# Altium schematic sheet Infrared Pro_ESP.SchDoc("Infrared Pro_ESP")
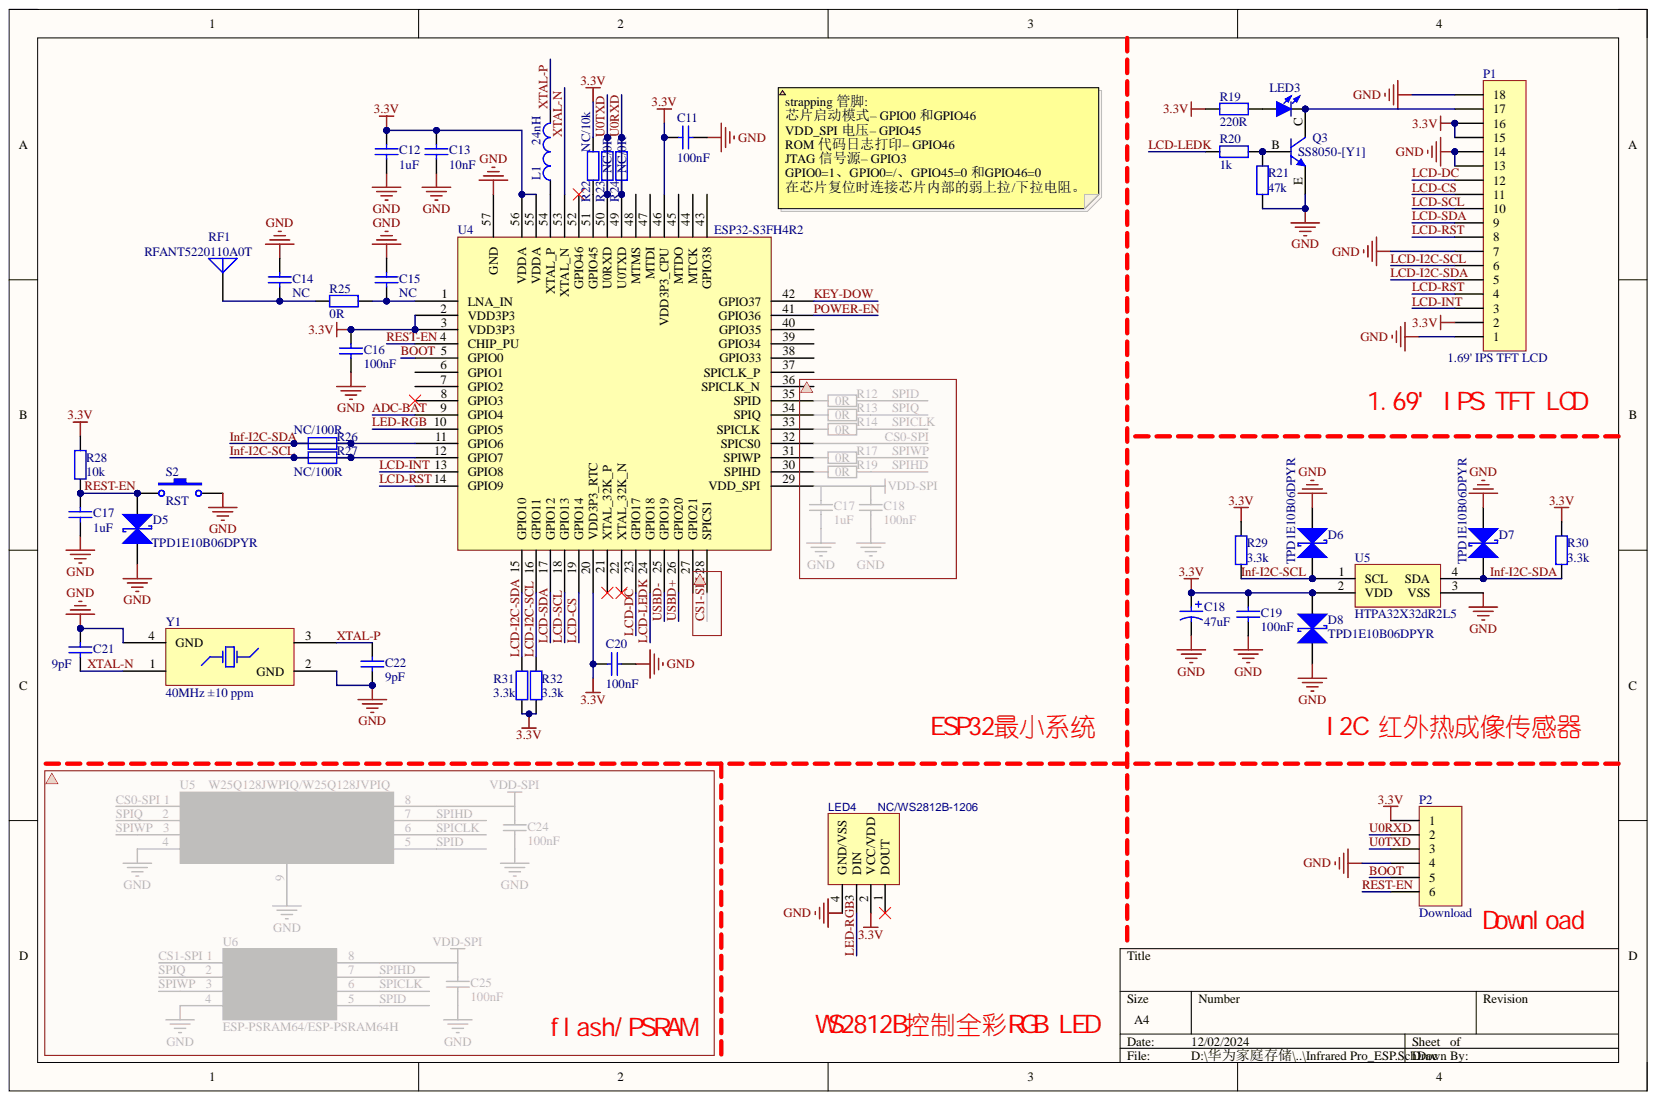
[diagram p0: Infrared Pro_ESP.SchDoc]

## Parts
C11  100nF  [C-RAD-0402-L]
C12  1uF  [C-RAD-0402-L]
C13  10nF  [C-RAD-0402-L]
C14  NC  [C-RAD-0402-L]
C15  NC  [C-RAD-0402-L]
C16  100nF  [C-RAD-0402-L]
C17  1uF  [C-RAD-0402-L]
C18  47uF  [C-CD A(3216-18)]
C19  100nF  [C-RAD-0402-L]
C20  100nF  [C-RAD-0402-L]
C21  9pF  [C-RAD-0402-L]
C22  9pF  [C-RAD-0402-L]
D5  TPD1E10B06DPYR  [X1-SON-2(0.6x1)]
D6  TPD1E10B06DPYR  [X1-SON-2(0.6x1)]
D7  TPD1E10B06DPYR  [X1-SON-2(0.6x1)]
D8  TPD1E10B06DPYR  [X1-SON-2(0.6x1)]
L1  24nH  [L 0402]
LED3  LED-SMD  [LED 0603-W-Side]  (value not drawn)
LED4  NC/WS2812B-1206  [LED-SMD-WS2812B-1206]
P1  1.69' IPS TFT LCD  [FPC_0.5_18P]
P2  Download  [TP-1.27mmx6]
Q3  SS8050-[Y1]  [Q-NPN-SOT23]
R19  220R  [RES-0402-L (1/16W)]
R20  1k  [RES-0402-L (1/16W)]
R21  47k  [RES-0402-L (1/16W)]
R22  NC/10k  [RES-0402-L (1/16W)]
R23  NC/0R  [RES-0402-L (1/16W)]
R24  NC/0R  [RES-0402-L (1/16W)]
R25  0R  [RES-0402-L (1/16W)]
R26  NC/100R  [RES-0402-L (1/16W)]
R27  NC/100R  [RES-0402-L (1/16W)]
R28  10k  [RES-0402-L (1/16W)]
R29  3.3k  [RES-0402-L (1/16W)]
R30  3.3k  [RES-0402-L (1/16W)]
R31  3.3k  [RES-0402-L (1/16W)]
R32  3.3k  [RES-0402-L (1/16W)]
RF1  RFANT5220110A0T  [ANT-AN5220]
S2  RST  [SW-SMD_YD-3410]
U4  ESP32-S3FH4R2  [QFN-56(7x7)]
U5  HTPA32X32dR2L5  [HTPA32X32dR2L5_B]
Y1  40MHz ±10 ppm  [Y-SMD 2016]

## Nets
3.3V: C11.1 C12.2 C13.2 C16.2 C18.1 C19.2 C20.1 D8.K LED4.2 P1.2 P1.15 P1.16 P2.1 R19.1 R22.2 R28.2 R29.2 R30.2 R31.1 R32.1 U4.2 U4.3 U4.20 U4.46 U4.55 U4.56 U5.2
GND: C11.2 C12.1 C13.1 C14.2 C15.2 C16.1 C17.1 C18.2 C19.1 C20.2 C21.2 C22.1 D5.A D6.K D7.K D8.A LED4.4 P1.1 P1.7 P1.13 P1.14 P1.18 P2.4 Q3.E R21.1 S2.1 U4.57 U5.3 Y1.2 Y1.4
ADC-BAT: U4.9
BOOT: P2.5 U4.5
Inf-I2C-SCL: D6.A R27.1 R27.2 R29.1 U4.12 U5.1
Inf-I2C-SDA: D7.A R26.1 R26.2 R30.1 U4.11 U5.4
KEY-DOW: U4.42
LCD-CS: P1.11 U4.19
LCD-DC: P1.12 U4.23
LCD-I2C-SCL: P1.6 R32.2 U4.16
LCD-I2C-SDA: P1.5 R31.2 U4.15
LCD-INT: P1.3 U4.13
LCD-LEDK: R20.2 U4.24
LCD-RST: P1.4 P1.8 U4.14
LCD-SCL: P1.10 U4.18
LCD-SDA: P1.9 U4.17
LED-RGB: LED4.3 U4.10
POWER-EN: U4.41
REST-EN: C17.2 D5.K P2.6 R28.1 S2.2 U4.4
U0RXD: P2.2 R24.1 R24.2 U4.49
U0TXD: P2.3 R23.1 R23.2 U4.50
USBD+: U4.26
USBD-: U4.25
XTAL-N: C21.1 U4.53 Y1.1
XTAL-P: C22.2 L1.2 Y1.3
NetC14_1: C14.1 R25.1 RF1.1
NetC15_1: C15.1 R25.2 U4.1
NetL1_1: L1.1 U4.54
NetLED3_1: LED3.1 R19.2
NetLED3_2: LED3.2 P1.17 Q3.C
NetQ3_B: Q3.B R20.1 R21.2
NetR22_1: R22.1 U4.51
Buzzer:
Motor:

Not connected: LED4 1; U4 6 7 8 21 22 27 28 29 30 31 32 33 34 35 36 37 38 39 40 43 44 45 47 48 52

## Library data of the parts
C11-C17 C19-C22 = Cap: Capacitor; Component Kind: Standard; LatestRevisionDate: 17-Jul-2002; LatestRevisionNote: Re-released for DXP Platform.; Library Name: Master Li.SchLib; PackageDescription: Radial Cap, Thru-Hole; 2 Leads; 0.3 in Pin Spacing; PackageReference: RAD-0.3; Published: 8-Jun-2000; Publisher: Altium Limited
  C11 C16 C19 C20: Value: 100nF
  C12 C17: Value: 1uF
  C13: Value: 10nF
  C14 C15: Value: NC
  C21 C22: Value: 9pF
C18 = Cap(tantalum): tantalum capacitor; Component Kind: Standard; Library Name: Master Li.SchLib; Value: 47uF
D5-D8 = Diode-TVS: 瞬变抑制二极管   TRANSIENT VOLTAGE SUPPRESSOR; Component Kind: Standard; LatestRevisionDate: 29-Mar-2024; LatestRevisionNote: Re-released for DXP Platform.; Library Name: Master Li.SchLib; Published: 29-Mar-2024; Publisher: Altium Limited
L1 = L-Inductor: 电感、磁珠; Code_IEC: 1005; Value: 100uH; Code_JEITA: 0402; Component Kind: Standard; LatestRevisionDate: 29-May-2009; LatestRevisionNote: IPC-7351 Footprint Added.; Library Name: Master Li.SchLib; PackageDescription: Chip Inductor; PackageReference: 0402-A; Published: 8-Jun-2000; Publisher: Altium Limited
LED3 = LED-SMD: 贴片LED; 版权归属: Master Li; BOM名称: 贴片LED; Component Kind: Standard; Library Name: Master Li.SchLib; 学习资源: https://shop237247974.taobao.com/
LED4 = LED-WS2812B-1206: 1206侧发光; BOM_Manufacturer: Worldsemi; BOM_Manufacturer Part: WS2812B-4020; BOM_JLCPCB Part Class: Extended Part; BOM_Supplier: LCSC; BOM_Supplier Part: C965557; Component Kind: Standard; Library Name: Master Li.SchLib; spicePre: L; spiceSymbolName: WS2812B-4020
P1 = Header 1x18: Header, 1x18-Pin; Component Kind: Standard; LatestRevisionDate: 17-Jul-2002; LatestRevisionNote: Re-released for DXP Platform.; Library Name: Master Li.SchLib; Publisher: Altium Limited
P2 = Header 1x6: Header, 1x6-Pin; Component Kind: Standard; LatestRevisionDate: 17-Jul-2002; LatestRevisionNote: Re-released for DXP Platform.; Library Name: Master Li.SchLib; Publisher: Altium Limited
Q3 = Q-NPN: NPN Bipolar Transistor; Code_JEDEC: TO-226-AA; Component Kind: Standard; LatestRevisionDate: 17-Jul-2002; LatestRevisionNote: Re-released for DXP Platform.; Library Name: Master Li.SchLib; PackageDescription: TO, Flat Index; 3 In-Line, Axial Leads; Body Dia. 4.8mm; Leads 0.55 x 0.51 mm (max); PackageReference: TO-226-AA; Published: 8-Jun-2000; Publisher: Altium Limited
R19-R32 = Res: Resistor; Component Kind: Standard; LatestRevisionDate: 17-Jul-2002; LatestRevisionNote: Re-released for DXP Platform.; Library Name: Master Li.SchLib; PackageDescription: Axial Device, Thru-Hole; 2 Leads; 0.4 in Pin Spacing; PackageReference: AXIAL-0.4; Published: 8-Jun-2000; Publisher: Altium Limited
  R19: Value: 220R
  R20: Value: 1k
  R21: Value: 47k
  R22: Value: NC/10k
  R23 R24: Value: NC/0R
  R25: Value: 0R
  R26 R27: Value: NC/100R
  R28: Value: 10k
  R29-R32: Value: 3.3k
RF1 = ANT-SMT: SMT贴片陶瓷天线; Component Kind: Standard; Library Name: Master Li.SchLib
S2 = SW PB - 2P: 2脚轻触按键; Component Kind: Standard; LatestRevisionDate: 17-Jul-2002; LatestRevisionNote: Re-released for DXP Platform.; Library Name: Master Li.SchLib; PackageDescription: Switch; 2 Leads; PackageReference: SPST-2; Published: 24-Mar-1999; Publisher: Altium Limited
U4 = ESP32-S3: ESP32-S3/S3FN8/S3R2/S3R8/S3R8V/S3R16V/S3FH4R2; Component Kind: Standard; Library Name: Master Li.SchLib
U5 = HTPA32X32dR2L5: 海曼HEIMANN 红外温度传感器; Component Kind: Standard; Library Name: Master Li.SchLib
Y1 = Y-XTAL-4P 无源: 无源晶体振荡器; Component Kind: Standard; LatestRevisionDate: 17-Jul-2002; LatestRevisionNote: Re-released for DXP Platform.; Library Name: Master Li.SchLib; PackageReference: R38; Published: 8-Jun-2000; Publisher: Altium Limited
